# Altium schematic sheet TX Chain.SchDoc("TX Chain")
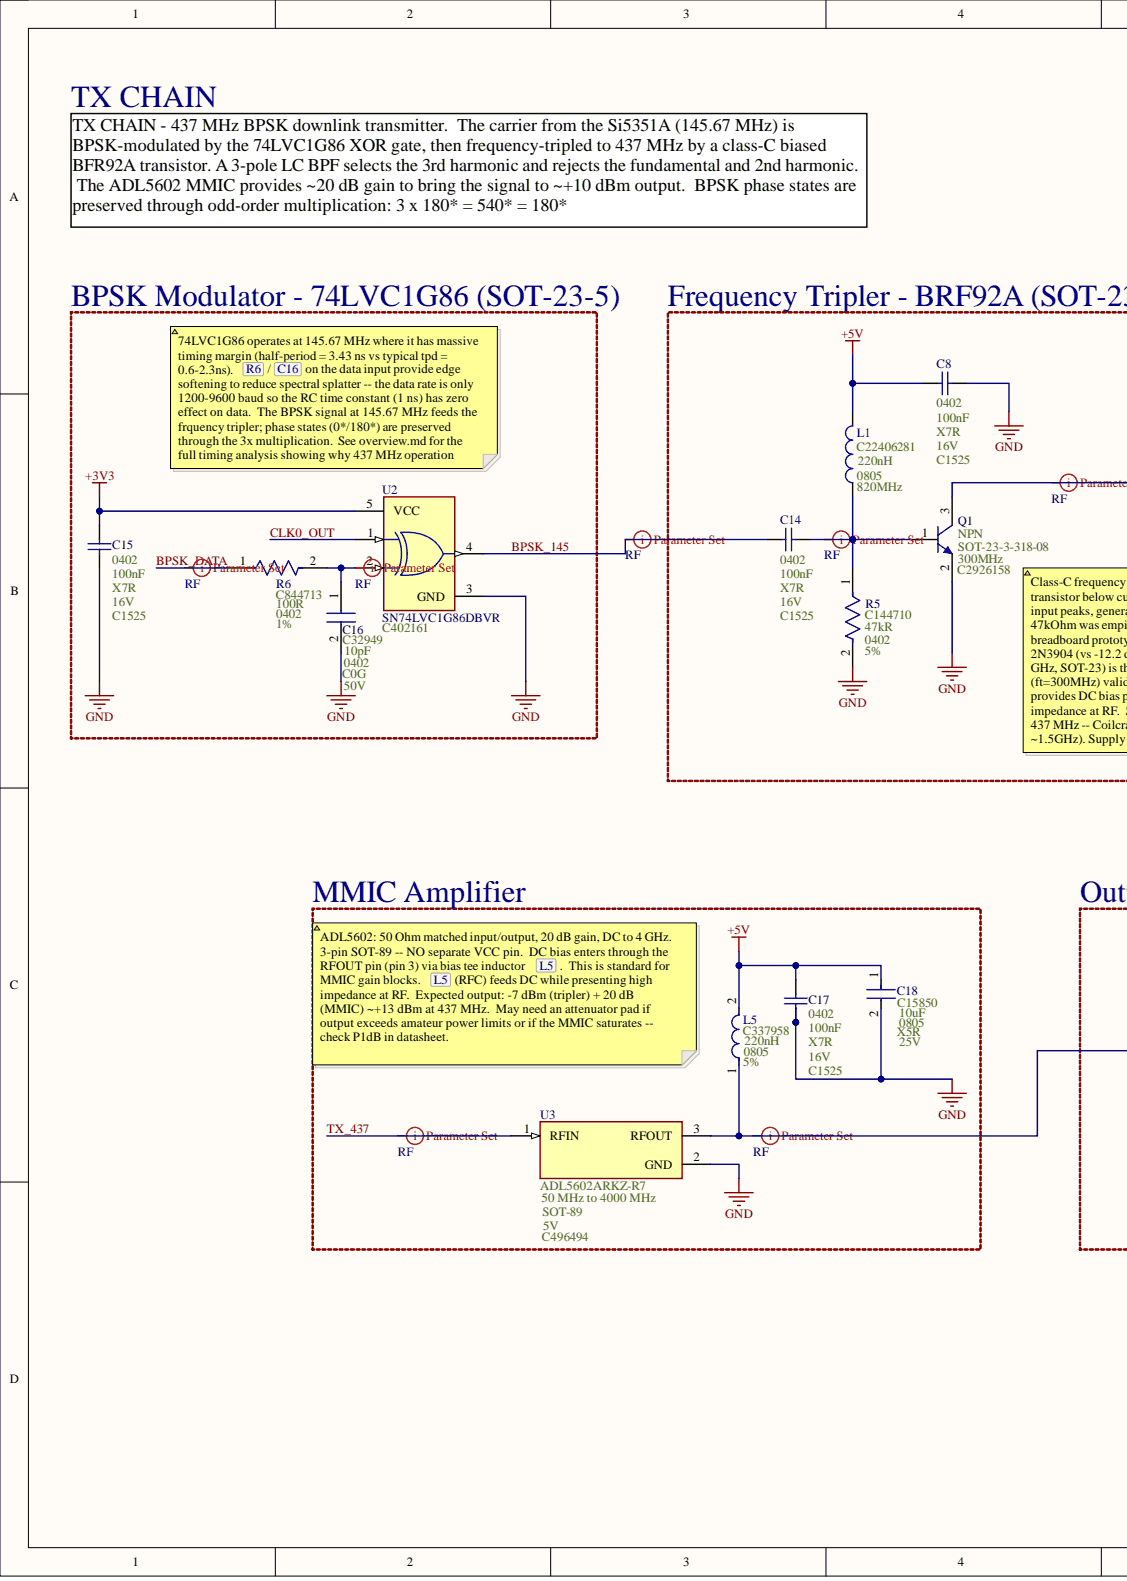
[diagram p0: TX Chain.SchDoc, left]
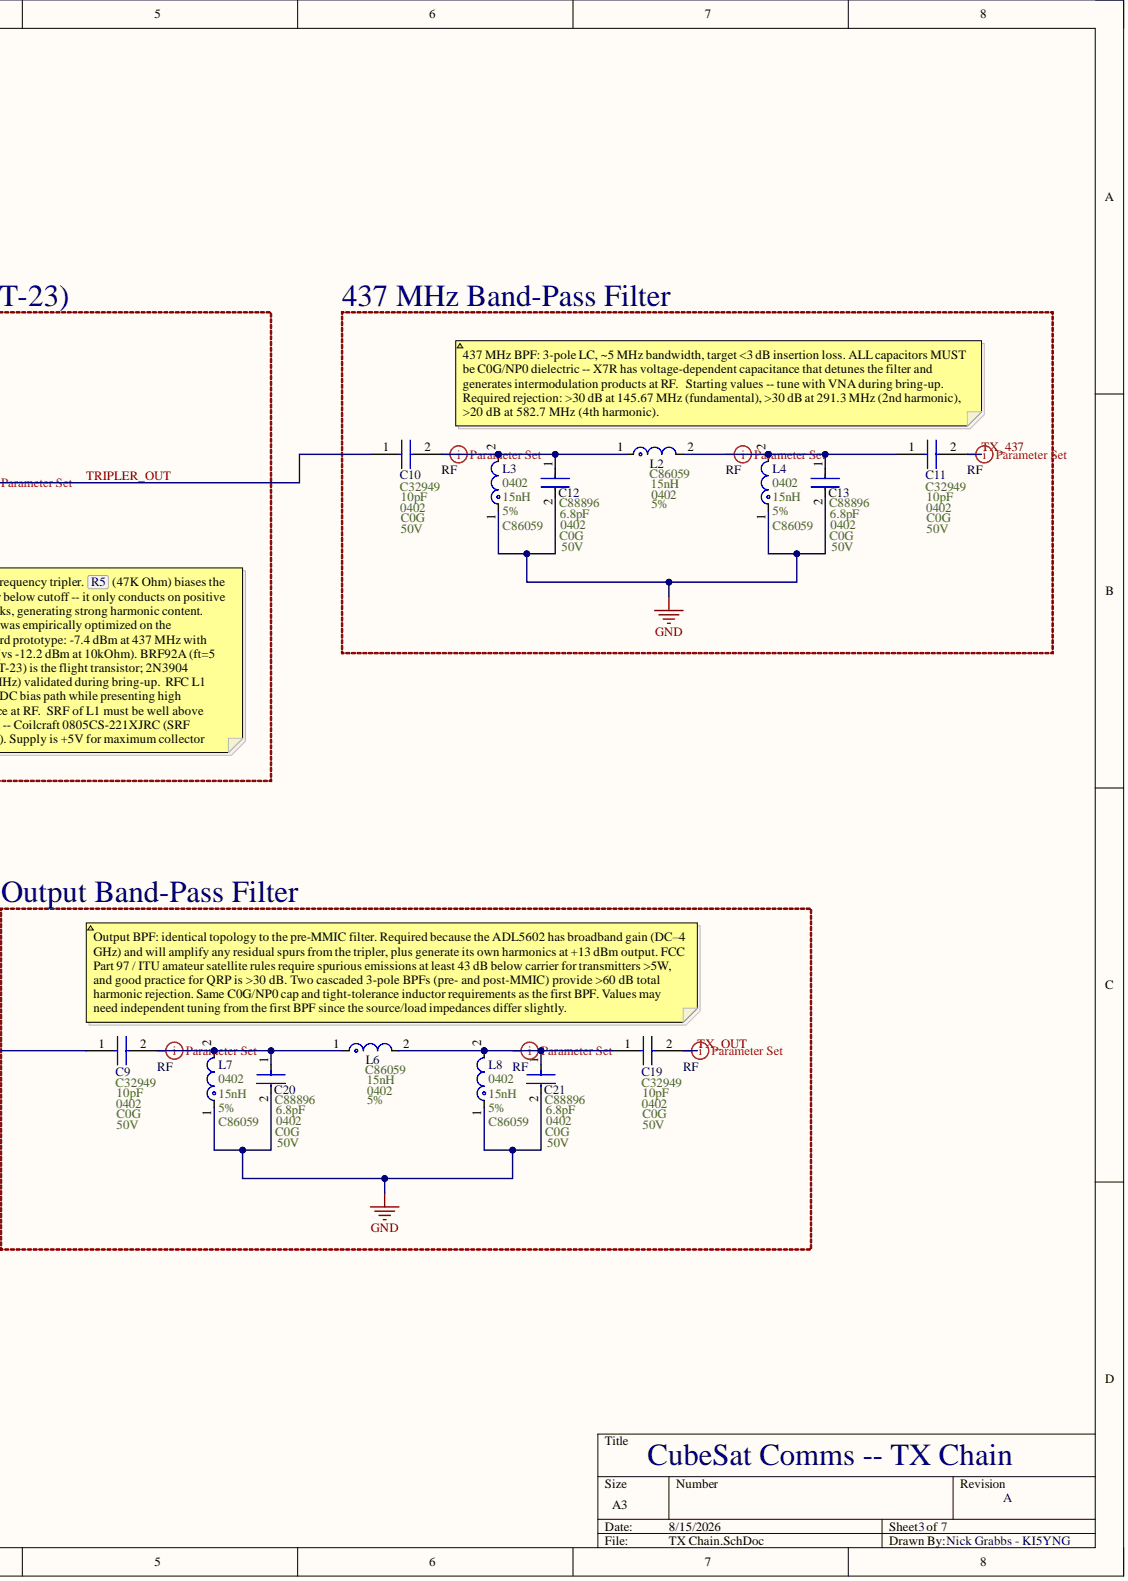
[diagram p1: TX Chain.SchDoc, right]

## Parts
C8  Capacitance: 100nF  Case Size/Dimension: 0402  Dielectric: X7R  (hidden comment: CL05B104KO5NNNC)
C9  value 10pF  Case: 0402  LCSC Part #: C32949  (hidden comment: CL05C100JB5NNNC)
C10  value 10pF  Case: 0402  LCSC Part #: C32949  (hidden comment: CL05C100JB5NNNC)
C11  value 10pF  Case: 0402  LCSC Part #: C32949  (hidden comment: CL05C100JB5NNNC)
C12  Capacitance: 6.8pF  Case Code (Imperial): 0402  Dielectric: C0G  LCSC Part #: C88896  (hidden comment: GJM1555C1H6R8BB01D)
C13  Capacitance: 6.8pF  Case Code (Imperial): 0402  Dielectric: C0G  LCSC Part #: C88896  (hidden comment: GJM1555C1H6R8BB01D)
C14  Capacitance: 100nF  Case Size/Dimension: 0402  Dielectric: X7R  (hidden comment: CL05B104KO5NNNC)
C15  Capacitance: 100nF  Case Size/Dimension: 0402  Dielectric: X7R  Rated Voltage: 16V  (hidden comment: CL05B104KO5NNNC)
C16  value 10pF  Case: 0402  Dielectric: C0G  LCSC Part #: C32949  Voltage: 50V  (hidden comment: CL05C100JB5NNNC)
C17  Capacitance: 100nF  Case Size/Dimension: 0402  Dielectric: X7R  Rated Voltage: 16V  (hidden comment: CL05B104KO5NNNC)
C18  Capacitance: 10uF  Case Code (Imperial): 0805  Dielectric: X5R  LCSC Part #: C15850  Voltage Rating: 25V  (hidden comment: CL21A106KAYNNNE)
C19  value 10pF  Case: 0402  LCSC Part #: C32949  (hidden comment: CL05C100JB5NNNC)
C20  Capacitance: 6.8pF  Case Code (Imperial): 0402  Dielectric: C0G  LCSC Part #: C88896  (hidden comment: GJM1555C1H6R8BB01D)
C21  Capacitance: 6.8pF  Case Code (Imperial): 0402  Dielectric: C0G  LCSC Part #: C88896  (hidden comment: GJM1555C1H6R8BB01D)
L1  value 220nH  Case/Package: 0805  LCSC Part #: C22406281  Self Resonant Frequency: 820MHz  (hidden comment: 0805CS-221XJLC)
L2  Case Code (Imperial): 0402  Inductance: 15nH  LCSC Part #: C86059  (hidden comment: LQG15HS15NJ02D)
L3  Case Code (Imperial): 0402  Inductance: 15nH  LCSC Part #: C86059  Tolerance: 5%  (hidden comment: LQG15HS15NJ02D)
L4  Case Code (Imperial): 0402  Inductance: 15nH  LCSC Part #: C86059  Tolerance: 5%  (hidden comment: LQG15HS15NJ02D)
L5  Case/Package: 0805  Inductance: 220nH  Inductance Tolerance: 5%  LCSC Part #: C337958  (hidden comment: LQW2BASR22J00L)
L6  Case Code (Imperial): 0402  Inductance: 15nH  LCSC Part #: C86059  (hidden comment: LQG15HS15NJ02D)
L7  Case Code (Imperial): 0402  Inductance: 15nH  LCSC Part #: C86059  Tolerance: 5%  (hidden comment: LQG15HS15NJ02D)
L8  Case Code (Imperial): 0402  Inductance: 15nH  LCSC Part #: C86059  Tolerance: 5%  (hidden comment: LQG15HS15NJ02D)
Q1  fT Min (MHz): 300MHz  LCSC Part #: C2926158  PackageReference: SOT-23-3-318-08  Polarity: NPN  (hidden comment: MMBT3904LT1G)
R5  Case Code (Imperial): 0402  LCSC Part #: C144710  Resistance: 47kR  Tolerance: 5%  (hidden comment: AC0402JR-0747KL)
R6  Case: 0402  LCSC Part #: C844713  Resistance: 100R  (hidden comment: CRCW0402100RFKEDC)
U2  SN74LVC1G86DBVR  LCSC Part #: C402161
U3  ADL5602ARKZ-R7  Case/Package: SOT-89  Operating Frequency: 50 MHz to 4000 MHz

## Nets
+3V3: C15.2 U2.5(VCC)
+5V: C8.2 C17.1 C18.1 L1.2 L5.2
GND: C8.1 C12.2 C13.2 C15.1 C16.2 C17.2 C18.2 C20.2 C21.2 L3.1 L4.1 L7.1 L8.1 Q1.2 R5.2 U2.3(GND) U3.2
BPSK_145: C14.2 U2.4
BPSK_DATA: R6.1
CLK0_OUT: U2.1
TRIPLER_OUT: C10.1 Q1.3
TX_437: C11.2 U3.1
TX_OUT: C19.2
NetC9_1: C9.1 L5.1 U3.3
NetC9_2: C9.2 C20.1 L6.1 L7.2
NetC10_2: C10.2 C12.1 L2.1 L3.2
NetC11_1: C11.1 C13.1 L2.2 L4.2
NetC14_1: C14.1 L1.1 Q1.1 R5.1
NetC16_1: C16.1 R6.2 U2.2(Parameter_Set)
NetC19_1: C19.1 C21.1 L6.2 L8.2

## Library data of the parts
C8 C14 = CMP-2000-05439-1: Chip Capacitor, 100 nF, +/- 10%, 16 V, -55 to 125 degC, 0402 (1005 Metric), RoHS, Tape and Reel; Manufacturer: Samsung Electro-Mechanics; Rated Voltage: 16V; Component Kind: Standard; Datasheet URL: https://datasheet.ciiva.com/29198/458718-da-01-en-vs-kondensator-0402-29198423.pdf; Datasheet Version: Nov-10; LCSC Part #: C1525; Library Name: Altium Content Vault; Manufacturer URL: http://www.samsungsem.com/global/index.jsp; Tolerance (%): ±10%
C9-C11 C19 = CL05C100JB5NNNC: Capacitor; Manufacturer_Name: SAMSUNG; Dielectric: C0G; Voltage: 50V; Arrow Part Number: CL05C100JB5NNNC; Arrow Price/Stock: https://www.arrow.com/en/products/cl05c100jb5nnnc/samsung-electro-mechanics?region=nac; Component Kind: Standard; Datasheet Link: http://www.samsungsem.com/global/support/product-search/mlcc/1204759_4880.html; Height: 0.55mm; Manufacturer_Part_Number: CL05C100JB5NNNC; Mouser Part Number: 187-CL05C100JB5NNNC; Mouser Price/Stock: https://www.mouser.co.uk/ProductDetail/Samsung-Electro-Mechanics/CL05C100JB5NNNC?qs=349EhDEZ59pnfPPyAlzfQw%3D%3D
C12 C13 C20 C21 = CMP-2008-03772-2: Multi-Layer Ceramic Capacitor 6.8pF C0G  ±0.1pF 0402 Paper T/R; Voltage Rating: 50V; Case Code (Metric): 1005; Case/Package: 0402; Component Kind: Standard; Depth: 0.5mm; Height: 0.5mm; Lead Free: Lead Free; Length: 1mm; Library Name: Altium Content Vault; Max Operating Temperature: 125°C; Min Operating Temperature: -55°C; Mount: Surface Mount; Package Quantity: 10000; Packaging: Tape and Reel; Radiation Hardening: No; Termination: SMD/SMT; Thickness: 0.022inch; Voltage Rating (DC): 50V; Width: 0.02inch
C15 C17 = CMP-2000-05439-1: Chip Capacitor, 100 nF, +/- 10%, 16 V, -55 to 125 degC, 0402 (1005 Metric), RoHS, Tape and Reel; Manufacturer: Samsung Electro-Mechanics; Component Kind: Standard; Datasheet URL: https://datasheet.ciiva.com/29198/458718-da-01-en-vs-kondensator-0402-29198423.pdf; Datasheet Version: Nov-10; LCSC Part #: C1525; Library Name: Altium Content Vault; Manufacturer URL: http://www.samsungsem.com/global/index.jsp; Tolerance (%): ±10%
C16 = CL05C100JB5NNNC: Capacitor; Manufacturer_Name: SAMSUNG; Arrow Part Number: CL05C100JB5NNNC; Arrow Price/Stock: https://www.arrow.com/en/products/cl05c100jb5nnnc/samsung-electro-mechanics?region=nac; Component Kind: Standard; Datasheet Link: http://www.samsungsem.com/global/support/product-search/mlcc/1204759_4880.html; Height: 0.55mm; Manufacturer_Part_Number: CL05C100JB5NNNC; Mouser Part Number: 187-CL05C100JB5NNNC; Mouser Price/Stock: https://www.mouser.co.uk/ProductDetail/Samsung-Electro-Mechanics/CL05C100JB5NNNC?qs=349EhDEZ59pnfPPyAlzfQw%3D%3D
C18 = CMP-13271-002975-1: CL21 Series 0805 10uF 25V ±10% Tolerance X5R Multilayer Ceramic Chip Capacitor; Case Code (Metric): 2012; Case/Package: 0805; Component Kind: Standard; Depth: 1.25mm; Height: 1.25mm; Length: 2mm; Library Name: Altium Content Vault; Max Operating Temperature: 85°C; Min Operating Temperature: -55°C; Mount: Surface Mount; Package Quantity: 1; Packaging: Tape and Reel; Radiation Hardening: No; Thickness: 0.057inch; Tolerance: 10%; Width: 0.049inch
L1 = CMP-006-00025-1: Ind Chip Wirewound 220nH 5% 100MHz 50Q-Factor Ceramic 400mA 0805 Embossed Plastic T/R; Component Kind: Standard; Max Current Rating: 400mA; Max Operating Temperature: 125°C; Min Operating Temperature: -40°C; Tolerance: 5%; Library Name: Nick Grabbs
L2-L4 L6-L8 = CMP-2100-03652-2: Multilayer type RF Inductor 15nH ±5% 0.32Ω 450mA 0402; Core Material: Air; Current Rating: 300mA; DC Resistance (DCR): 320mR; Q Factor: 8; Self Resonant Frequency: 2.5GHz; Shielding: Unshielded; Test Frequency: 100MHz; Case Code (Metric): 1005; Case/Package: 0402; Component Kind: Standard; DC Current: 300mA; Depth: 0.55mm; Height: 0.5mm; Height - Seated (Max): 0.022inch; Lead Free: Lead Free; Length: 1mm; Library Name: Altium Content Vault; Max DC Current: 300mA; Max Operating Temperature: 125°C; Military Standard: Not; Min Operating Temperature: -55°C; Mount: Surface Mount; Package Quantity: 10000; Packaging: Tape and Reel; Radiation Hardening: No; Termination: SMD/SMT; Width: 0.02inch
  L2 L6: Tolerance: 5%
L5 = CMP-06042-011308-1: Wire Wound RF Inductor 220nH ±5% 400mA 0.7Ω 0805 (2015); Core Material: Air; DC Resistance (DCR): 700mR; Q Factor: 50; Self Resonant Frequency: 820MHz; Shielding: Unshielded; Test Frequency: 100MHz; Case Code (Imperial): 0806; Case Code (Metric): 2015; Component Kind: Standard; Current Rating: 400mA; DC Current: 400mA; Depth: 1.73mm; Height: 1.42mm; Height - Seated (Max): 0.06inch; Lead Free: Lead Free; Length: 0.082inch; Library Name: Altium Content Vault; Max DC Current: 400mA; Max Operating Temperature: 125°C; Military Standard: Not; Min Operating Temperature: -55°C; Mount: Surface Mount; Package Quantity: 2000; Packaging: Tape and Reel; Radiation Hardening: No; REACH SVHC: No SVHC; Resistor Type: Wirewound; Termination: SMD/SMT; Tolerance: 5%; Weight: 0.000494oz; Width: 1.5mm
Q1 = CMP-1048-00631-1: General Purpose Transistor, NPN Silicon, 3-Pin SOT-23, Pb-Free, Tape and Reel; Manufacturer: On Semiconductor; IC Continuous (A): 0.2; Component Kind: Standard; ComponentLink1Description: Manufacturer URL; ComponentLink1URL: http://www.onsemi.com/; ComponentLink2Description: Datasheet; ComponentLink2URL: http://www.onsemi.cn/pub_link/Collateral/MMBT3904LT1-D.PDF; ComponentLink3Description: Package Specification; ComponentLink3URL: http://www.onsemi.cn/pub_link/Collateral/318-08.PDF; DatasheetVersion: Rev. 12; Library Name: Altium Content Vault; Mounting Technology: Surface Mount; PackageDescription: 3-Pin Small Outline Transistor, Body 2.92 x 1.3 mm, Pitch 0.95 mm; PackageVersion: Rev. AP, 11/2009; Packing: Tape and Reel; PartNumber: MMBT3904LT1G; RoHS: TRUE
R5 = CMP-2002-01760-2: RES 47kΩ ±5% 0.063W 0402; Max Power Dissipation: 63mW; Power Rating: 62.5mW; Temperature Coefficient: 100ppm/°C; Voltage Rating: 50V; Case Code (Metric): 1005; Case/Package: 0402; Component Kind: Standard; Features: Moisture Resistant; Height: 0.015inch; Lead Free: Lead Free; Length: 0.039inch; Library Name: Altium Content Vault; Max Operating Temperature: 155°C; Min Operating Temperature: -55°C; Number of Terminations: 2; Packaging: Tape and Reel; Resistor Type: Thick Film; RoHS Compliant: Yes; Series: AC; Size Code: 0402; Termination: SMD/SMT; Width: 0.5mm
R6 = CMP-02407-011580-1: RES 100 OHM 1% 1/16W 0402; Power Rating: 62.5mW; Temperature Coefficient: 100ppm/°C; Case/Package: SMD/SMT; Component Kind: Standard; Contact Plating: Tin; Lead Free: Lead Free; Length: 1mm; Library Name: Altium Content Vault; Max Operating Temperature: 155°C; Min Operating Temperature: -55°C; Mount: Surface Mount; Resistor Type: Thick Film; RoHS Compliant: Yes; Termination: Wraparound; Tolerance: 1%
U2 = CMP-0859-00174-4: IC GATE XOR 1CH 2-INP SOT23-5; Manufacturer: Texas Instruments; Logic Function Desc: Non-Inverting; Max Junction Temp: +150°C; Max Supply Voltage: 5.5V; Min Supply Voltage: 1.65V; Nominal Supply Current: 15uA; Number Of Circuits: 1; Number Of Inputs: 2; Propagation Delay: 5ns; Standoff Height: 0mm; Ambient Temperature Range High: +125°C; Ambient Temperature Range Low: -40°C; Automotive: No; Category: IC; Component Kind: Standard; ComponentLink2Description: Datasheet; ComponentLink2URL: http://www.ti.com/lit/ds/symlink/sn74lvc1g86.pdf; Device Class L1: Integrated Circuits (ICs); Device Class L2: Logic ICs; Device Class L3: Logic Gates; Digikey Description: IC GATE XOR 1CH 2-INP SOT23-5; Height: 1.45mm; Ipc Land Pattern Name: SOT95P280X145-5; Lead Free: Yes; Library Name: Altium Content Vault; Manufacturer Part Number: SN74LVC1G86DBVR; Mouser Description: Logic Gates 2 Input; Number Of Outputs: 1; Octopart: https://octopart.com/sn74lvc1g86dbvr-texas+instruments-465564; Package: DBV0005A; RoHS: TRUE; Temperature: -40°C to +125°C; Temperature Range High: +125°C; Temperature Range Low: -40°C
U3 = CMP-09142-000120-1: IC AMP CELLULAR 50MHZ-4GHZ SOT89; Amplifier Type: LINEAR; Frequency: 4GHz; Gain: 19.5dB; Max Power Dissipation: 600mW; Max Supply Voltage: 5.5V; Min Frequency: 50MHz; Min Supply Voltage: 4.5V; Noise Figure: 3.3dB; Nominal Supply Current: 89mA; Number of Channels: 1; Operating Supply Voltage: 5V; P1dB: 19.3dBm; Test Frequency: 2GHz; Component Kind: Standard; Height: 1.6mm; LCSC Part #: C496494; Lead Free: Contains Lead; Length: 4.6mm; Library Name: Altium Content Vault; Max Frequency: 4GHz; Max Operating Temperature: 85°C; Min Operating Temperature: -40°C; Mount: Surface Mount; Number of Pins: 3; Operating Supply Current: 89mA; Package Quantity: 1; Packaging: Tape and Reel; Power Dissipation: 600mW; Radiation Hardening: No; REACH SVHC: No SVHC; RoHS Compliant: Yes; Series: -; Supply Current: 89mA; Width: 2.6mm
